# Altium schematic sheet mainBoard.SchDoc
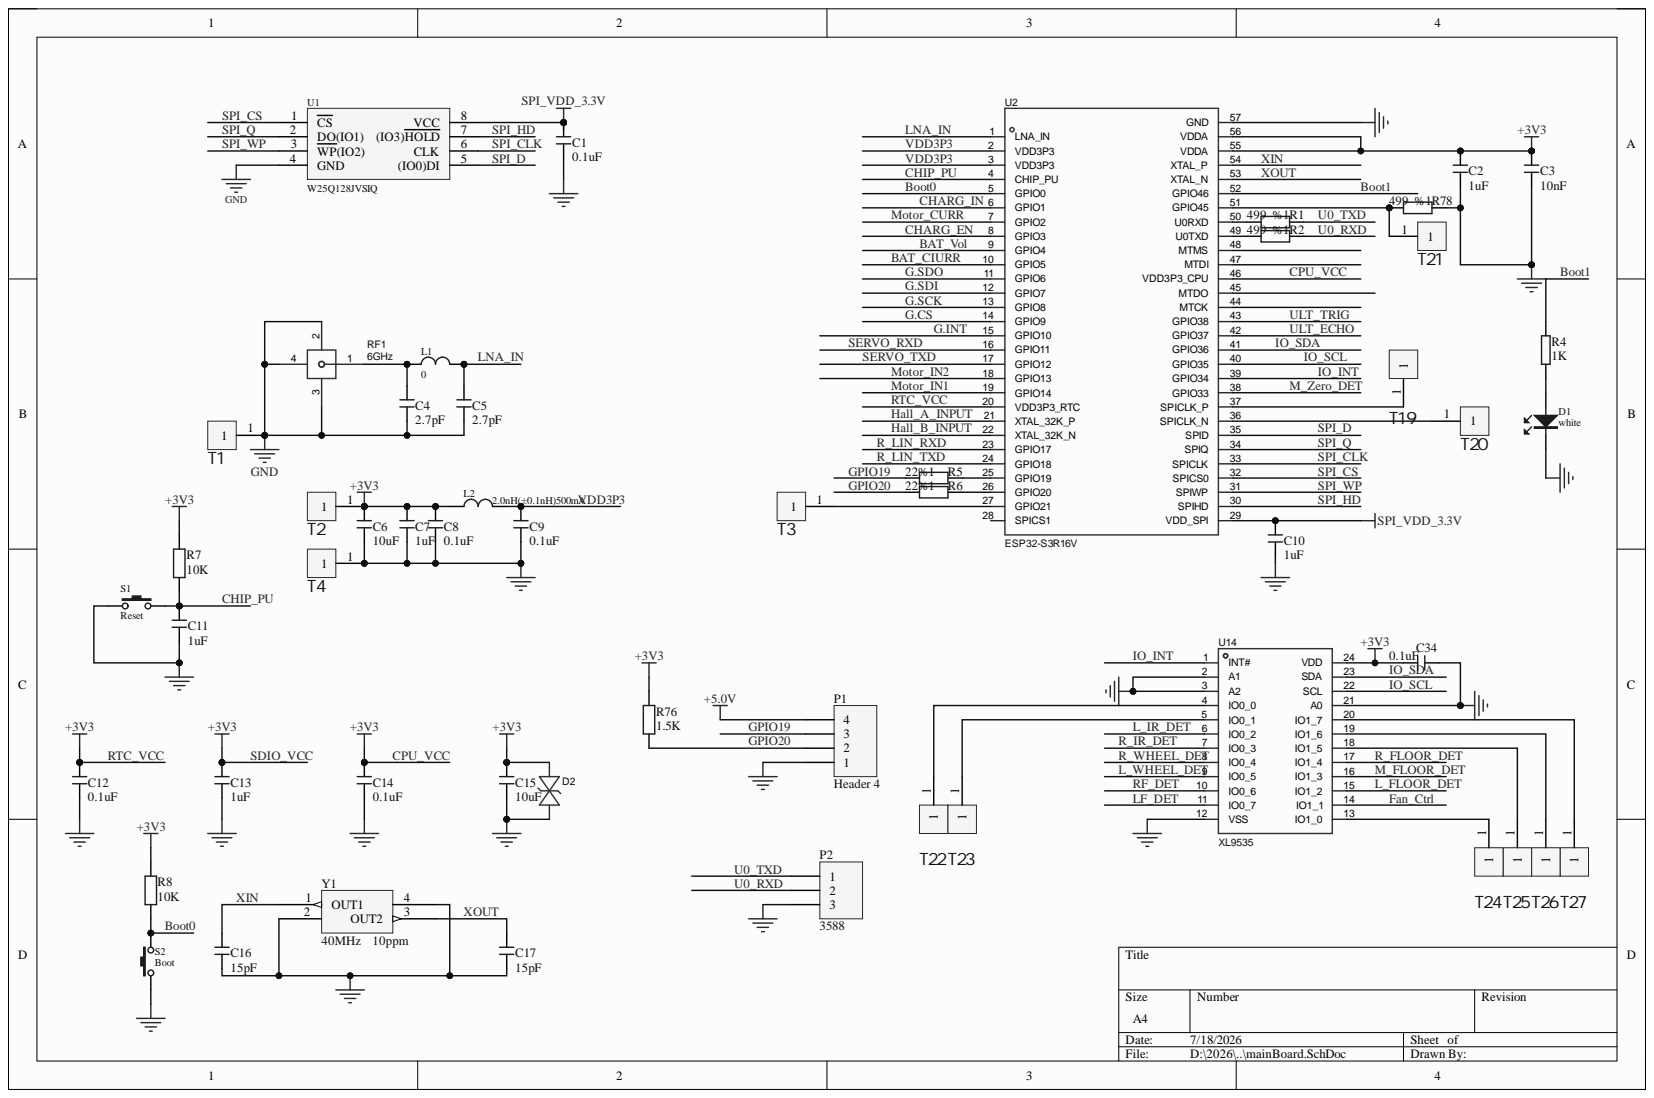
[diagram p0: mainBoard.SchDoc]

## Parts
C1  0.1uF  [C 0603_L]
C2  1uF  [C 0603_L]
C3  10nF  [C 0603_L]
C4  2.7pF  [C 0603_L]
C5  2.7pF  [C 0603_L]
C6  10uF  [C 0603_L]
C7  1uF  [C 0603_L]
C8  0.1uF  [C 0603_L]
C9  0.1uF  [C 0603_L]
C10  1uF  [C 0603_L]
C11  1uF  [C 0603_L]
C12  0.1uF  [C 0603_L]
C13  1uF  [C 0603_L]
C14  0.1uF  [C 0603_L]
C15  10uF  [C 0603_L]
C16  15pF  [C 0603_L]
C17  15pF  [C 0603_L]
C34  0.1uF  [C 0603_L]
D1  white  [L 0603]
D2  PSD03C-LF-T7  [SOD-323_L1.8-W1.3-LS2.5-BI]  (value not drawn)
L1  0  [L 0603]
L2  2.0nH(±0.1nH)500mA  [L 0603]
P1  Header 4  [XH2.54-LS-4P]
P2  3588  [XH2.54-LS-3P]
R1  499  %1  [R 0603_L]
R2  499  %1  [R 0603_L]
R4  1K  [R 0603_L]
R5  22%1  [R 0603_L]
R6  22%1  [R 0603_L]
R7  10K  [R 0603_L]
R8  10K  [R 0603_L]
R76  1.5K  [R 0603_L]
R78  499  %1  [R 0603_L]
RF1  6GHz  [IPEX-SMD_BWIPX-4-001E]
S1  Reset  [TSW SMD-3*6*2.5]
S2  Boot  [TSW SMD-3*6*2.5]
T1  (no value)  [TestPin_1mm]
T2  (no value)  [TestPin_1mm]
T3  (no value)  [TestPin_1mm]
T4  (no value)  [TestPin_1mm]
T19  (no value)  [TestPin_1mm]
T20  (no value)  [TestPin_1mm]
T21  (no value)  [TestPin_1mm]
T22  (no value)  [TestPin_1mm]
T23  (no value)  [TestPin_1mm]
T24  (no value)  [TestPin_1mm]
T25  (no value)  [TestPin_1mm]
T26  (no value)  [TestPin_1mm]
T27  (no value)  [TestPin_1mm]
U1  W25Q128JVSIQ  [SOIC-8_L5.3-W5.3-P1.27-LS8.0-BL]
U2  ESP32-S3R16V  [QFN-56_L7.0-W7.0-P0.40-TL-EP4.0]
U14  XL9535  [TSSOP-24_L7.8-W4.4-P0.65-LS6.4-TL]
Y1  40MHz    10ppm  [OSC 3225-4P]

## Nets
+5.0V: P1.4
GND: C1.2 C2.2 C3.2 C4.2 C5.2 C6.2 C7.2 C8.2 C9.2 C10.2 C11.2 C12.2 C13.2 C14.2 C15.2 C16.1 C17.1 C34.2 D1.2 D2.1 P1.1 P2.3 R78.2 RF1.2 RF1.3 RF1.4 S1.2 S2.2 T1.1 T4.1 U1.4(GND) U2.57(GND) U14.2(A1) U14.3(A2) U14.12(VSS) U14.21(A0) Y1.2 Y1.4
SPI_VDD_3.3V: C1.1 C10.1 U1.8(VCC) U2.29(VDD_SPI)
BAT_CIURR: U2.10(GPIO5)
BAT_Vol: U2.9(GPIO4)
Boot0: R8.1 S2.1 U2.5(GPIO0)
Boot1: R4.1 U2.52(GPIO46)
CHARG_EN: U2.8(GPIO3)
CHARG_IN: U2.6(GPIO1)
CHIP_PU: C11.1 R7.1 S1.1 U2.4(CHIP_PU)
CPU_VCC: C2.1 C3.1 C6.1 C7.1 C8.1 C12.1 C13.1 C14.1 C15.1 C34.1 D2.2 L2.1 R7.2 R8.2 R76.1 T2.1 U2.20(VDD3P3_RTC) U2.46(VDD3P3_CPU) U2.55(VDDA) U2.56(VDDA) U14.24(VDD)
Fan_Ctrl: U14.14(IO1_1)
G.CS: U2.14(GPIO9)
G.INT: U2.15(GPIO10)
G.SCK: U2.13(GPIO8)
G.SDI: U2.12(GPIO7)
G.SDO: U2.11(GPIO6)
GPIO19: P1.3 R5.1
GPIO20: P1.2 R6.1 R76.2
Hall_A_INPUT: U2.21(XTAL_32K_P)
Hall_B_INPUT: U2.22(XTAL_32K_N)
IO_INT: U2.39(GPIO34) U14.1(INT#)
IO_SCL: U2.40(GPIO35) U14.22(SCL)
IO_SDA: U2.41(GPIO36) U14.23(SDA)
L_FLOOR_DET: U14.15(IO1_2)
L_IR_DET: U14.6(IO0_2)
L_WHEEL_DET: U14.9(IO0_5)
LF_DET: U14.11(IO0_7)
LNA_IN: C5.1 L1.2 U2.1(LNA_IN)
M_FLOOR_DET: U14.16(IO1_3)
M_Zero_DET: U2.38(GPIO33)
Motor_CURR: U2.7(GPIO2)
Motor_IN1: U2.19(GPIO14)
Motor_IN2: U2.18(GPIO13)
R_FLOOR_DET: U14.17(IO1_4)
R_IR_DET: U14.7(IO0_3)
R_LIN_RXD: U2.23(GPIO17)
R_LIN_TXD: U2.24(GPIO18)
R_WHEEL_DET: U14.8(IO0_4)
RF_DET: U14.10(IO0_6)
SERVO_RXD: U2.16(GPIO11)
SERVO_TXD: U2.17(GPIO12)
SPI_CLK: U1.6(CLK) U2.33(SPICLK)
SPI_CS: U1.1(CS) U2.32(SPICS0)
SPI_D: U1.5((IO0)DI) U2.35(SPID)
SPI_HD: U1.7((IO3)HOLD) U2.30(SPIHD)
SPI_Q: U1.2(DO(IO1)) U2.34(SPIQ)
SPI_WP: U1.3(WP(IO2)) U2.31(SPIWP)
U0_RXD: P2.2 R2.2
U0_TXD: P2.1 R1.2
ULT_ECHO: U2.42(GPIO37)
ULT_TRIG: U2.43(GPIO38)
VDD3P3: C9.1 L2.2 U2.2(VDD3P3) U2.3(VDD3P3)
XIN: C16.2 U2.54(XTAL_P) Y1.1(OUT1)
XOUT: C17.2 U2.53(XTAL_N) Y1.3(OUT2)
NetC4_1: C4.1 L1.1 RF1.1
NetD1_1: D1.1 R4.2
NetR1_1: R1.1 U2.50(U0RXD)
NetR2_1: R2.1 U2.49(U0TXD)
NetR5_2: R5.2 U2.25(GPIO19)
NetR6_2: R6.2 U2.26(GPIO20)
NetR78_1: R78.1 T21.1 U2.51(GPIO45)
NetT3_1: T3.1 U2.27(GPIO21)
NetT19_1: T19.1 U2.37(SPICLK_P)
NetT20_1: T20.1 U2.36(SPICLK_N)
NetT22_1: T22.1 U14.4(IO0_0)
NetT23_1: T23.1 U14.5(IO0_1)
NetT24_1: T24.1 U14.13(IO1_0)
NetT25_1: T25.1 U14.18(IO1_5)
NetT26_1: T26.1 U14.19(IO1_6)
NetT27_1: T27.1 U14.20(IO1_7)

Not connected: U2 28(SPICS1) 44(MTCK) 45(MTDO) 47(MTDI) 48(MTMS)

## Library data of the parts
C1-C17 C34 = C: 贴片电容; Component Kind: Standard; Library Name: GS_SchLib.SchLib
D1 = LED: Component Kind: Standard; Library Name: HX_General.IntLib
D2 = PSD03C-LF-T7: 极性:双向;反向截止电压(Vrwm):3.3V;击穿电压(最小值):4V;最大钳位电压:7V;; Manufacturer: PROTEK; AddInBom: yes; Breakdown Voltage (Min): 4V; Maximum Clamping Voltage: 7V; ModelId: e9dd044ee7bf4221b3b795eb56bce7c0聨0819f05c4eef4c71ace90d822a990e87; ModelName: SOD-323_L1.7-W1.3-H1.1-LS2.5; ModelTransform: 98.9,51.2,0,0,0,0,0,0,0; Polarity: 双向; Reverse Stand-Off Voltage (Vrwm): 3.3V; Component Kind: Standard; ConvertToPcb: yes; Datasheet: https://atta.szlcsc.com/upload/public/pdf/source/20171026/C139300_15089856513231059587.pdf; Device: PSD03C-LF-T7; FootprintName: SOD-323_L1.8-W1.3-LS2.5-BI; JLCPCB Part Class: Extended Part; LCSC Part Name: 双向 3.3V; ManufacturerPart: PSD03C-LF-T7; Supplier: LCSC; Supplier Footprint: SOD-323; SupplierPart: C139300; Symbol: PSD03C-LF-T7
L1 L2 = INDUCTOR: Component Kind: Standard; Library Name: HX_General.IntLib
P1 = Header 4: Header, 4-Pin; Component Kind: Standard; LatestRevisionDate: 17-Jul-2002; LatestRevisionNote: Re-released for DXP Platform.; Library Name: Miscellaneous Connectors.IntLib; Signal Integrity: Connector; Publisher: Altium Limited
P2 = Header 3: Header, 3-Pin; Component Kind: Standard; LatestRevisionDate: 17-Jul-2002; LatestRevisionNote: Re-released for DXP Platform.; Library Name: Miscellaneous Connectors.IntLib; Signal Integrity: Connector; Publisher: Altium Limited
R1 R2 R5-R8 R76 R78 = R: 贴片电阻; Component Kind: Standard; Library Name: GS_SchLib.SchLib
R4 = RES3-SMD: SMD筿; Component Kind: Standard; Library Name: Orson SCH.SCHLIB
RF1 = BWIPX-4-001E: 连接器类型:板端;接口类型:内针;端口数量:1;接口直径:1.5mm;接口长度/高度:0.6mm;频率:6GHz;射频系列:IPEX;阻抗:50Ω;样式:-;工作温度:-40℃~+85℃;; Manufacturer: BAT WIRELESS(蝙蝠无线); AddInBom: yes; Connector Type: 板端; Impedance: 50Ω; Interface: 内针; Interface Diameter: 1.5mm; Interface Length/Height: 0.6mm; ModelId: 4a204218fd944fc495fde1019670ac0d聨0819f05c4eef4c71ace90d822a990e87; ModelTransform: 78.74,78.74,0,0,0,0,0,-9.842,0; Number of Ports: 1; RF Series: IPEX; Component Kind: Standard; ConvertToPcb: yes; Datasheet: https://item.szlcsc.com/datasheet/BWIPX-4-001E/839643.html; Device: BWIPX-4-001E; FootprintName: IPEX-SMD_BWIPX-4-001E; JLCPCB Part Class: Extended Part; LCSC Part Name: 内针 IPEX; ManufacturerPart: BWIPX-4-001E; ModelName: IPEX-SMD_BWIPX-4-001E; Operating Temperature Range: -40℃~+85℃; Style: -; Supplier: LCSC; Supplier Footprint: SMD; SupplierPart: C784401; Symbol: BWIPX-4-001E; Value: 6GHz
S1 S2 = SW-PB: Component Kind: Standard; Library Name: HX_General.IntLib
T1-T4 T19-T27 = CON1: Connector; Component Kind: Standard
U1 = W25Q16BV: Component Kind: Standard; Library Name: HX_IC.IntLib
U2 = ESP32-S3R16V: AddInBom: yes; ModelId: 105b388c0c03439aa7dbf35dd2b762a6聨0819f05c4eef4c71ace90d822a990e87; ModelTransform: 275.59,275.59,0,0,0,0,0,0,0; Component Kind: Standard; ConvertToPcb: yes; Device: ESP32-S3R16V; FootprintName: QFN-56_L7.0-W7.0-P0.40-TL-EP4.0; LCSC Part Name: ESP32-S3R16V; ManufacturerPart: ESP32-S3R16V; ModelName: QFN-56_L7.0-W7.0-P0.40-TL-EP4.0; Supplier: LCSC; Supplier Footprint: QFN56; SupplierPart: C9900201184; Symbol: ESP32-S3R16V
U14 = XL9535: 接口类型:I2C；SMBus;I/O数量:16;时钟频率(fc):400kHz;; Manufacturer: XINLUDA(信路达); AddInBom: yes; Clock Frequency: 400kHz; Interrupt Output: 有中断输出; ModelId: 57e278220af748a2882c4abba4094eb9聨0819f05c4eef4c71ace90d822a990e87; ModelName: TSSOP-24_L7.8-W4.4-H1.0-LS6.4-P0.65; ModelTransform: 251.969,307.087,0,0,0,0,-0.001,0.007,0; Number of I/O: 16; Voltage - Supply: 2.3V~5.5V; Component Kind: Standard; ConvertToPcb: yes; Current - Output High(IOH): -; Current - Output Low(IOL): -; Datasheet: https://item.szlcsc.com/datasheet/XL9535/586603.html; Device: XL9535; FootprintName: TSSOP-24_L7.8-W4.4-P0.65-LS6.4-TL; Interface: I2C；SMBus; JLCPCB Part Class: Extended Part; LCSC Part Name: 16位I²C总线和SMBus I/O端口扩展器，带中断输出和配置寄存器; ManufacturerPart: XL9535; Operating Temperature: -40℃~+85℃; Output Type: -; Supplier: LCSC; Supplier Footprint: TSSOP-24; SupplierPart: C561273; Symbol: XL9535
Y1 = OSC: Component Kind: Standard; Library Name: GS_SchLib.SchLib
